# Altium schematic sheet Display.SchDoc
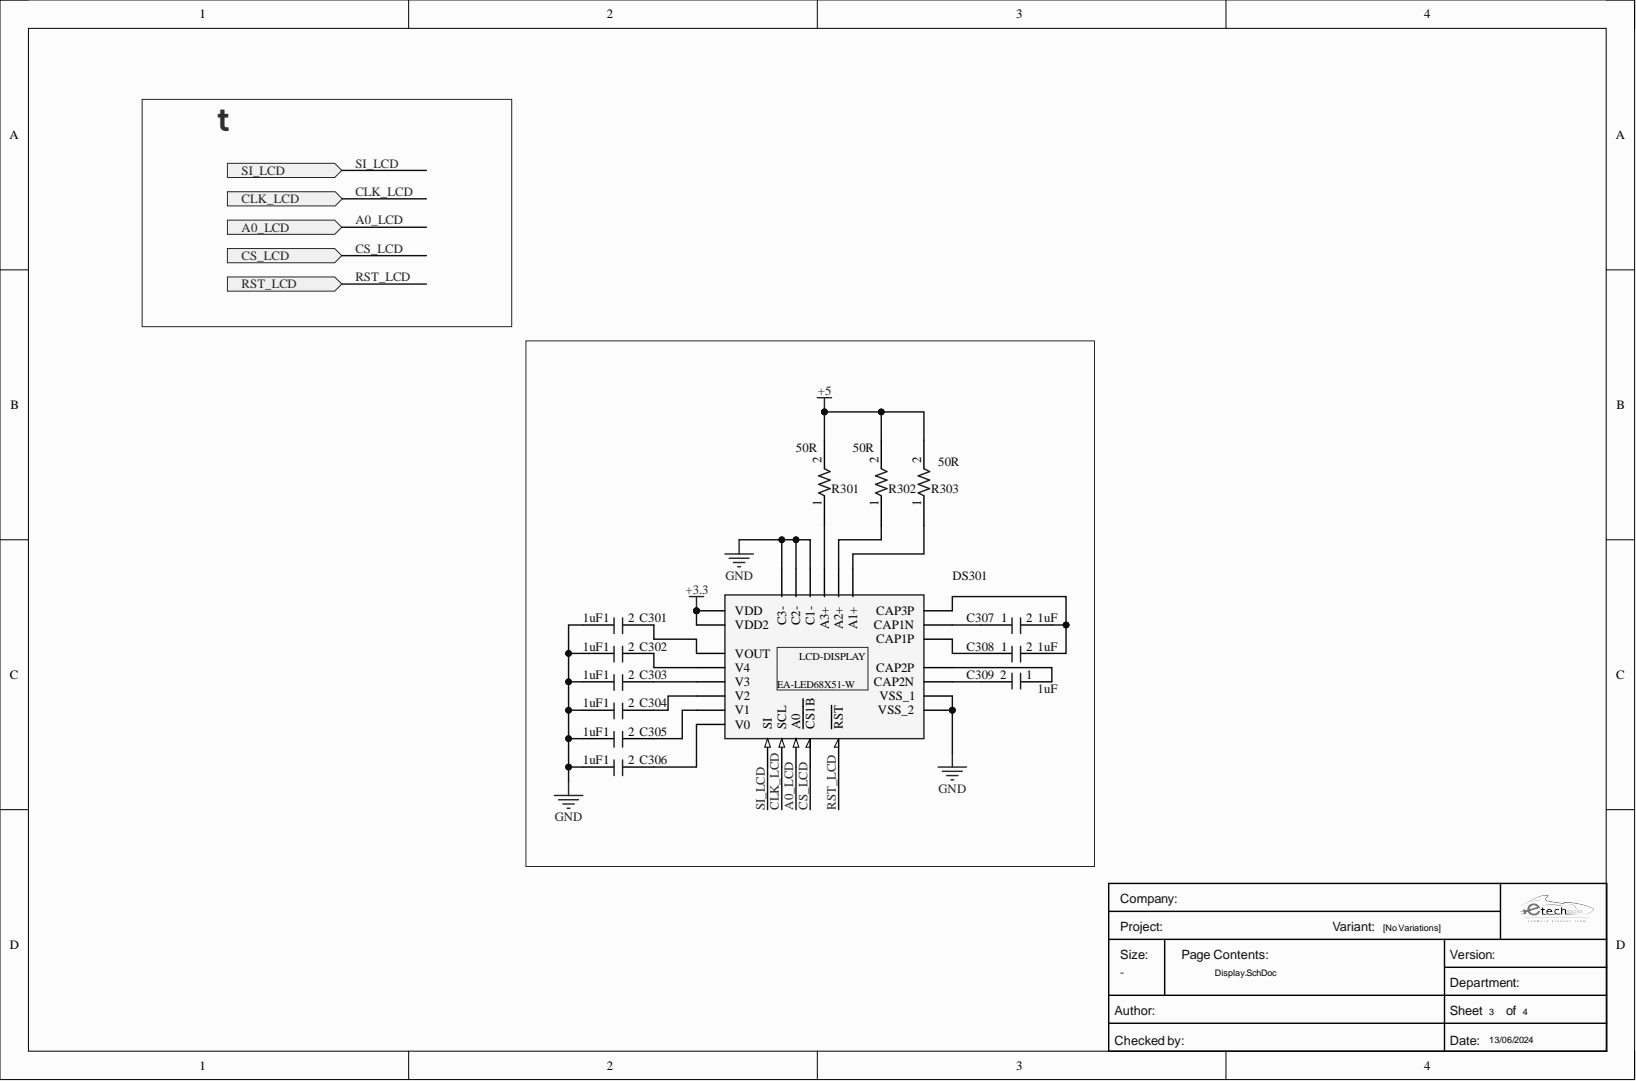
[diagram p0: Display.SchDoc]

## Parts
C301  Capacitance: 1uF  [C0805FNPC-R]  (hidden comment: 885012207103)
C302  Capacitance: 1uF  [C0805FNPC-R]  (hidden comment: 885012207103)
C303  Capacitance: 1uF  [C0805FNPC-R]  (hidden comment: 885012207103)
C304  Capacitance: 1uF  [C0805FNPC-R]  (hidden comment: 885012207103)
C305  Capacitance: 1uF  [C0805FNPC-R]  (hidden comment: 885012207103)
C306  Capacitance: 1uF  [C0805FNPC-R]  (hidden comment: 885012207103)
C307  Capacitance: 1uF  [C0805FNPC-R]  (hidden comment: 885012207103)
C308  Capacitance: 1uF  [C0805FNPC-R]  (hidden comment: 885012207103)
C309  Capacitance: 1uF  [C0805FNPC-R]  (hidden comment: 885012207103)
DS301  EA_DOGL128W-6  [EA_DOGL128W-6]  (value not drawn)
R301  value 50R  [R0805FTK]  (hidden comment: CRCW080550R0FKTA)
R302  value 50R  [R0805FTK]  (hidden comment: CRCW080550R0FKTA)
R303  value 50R  [R0805FTK]  (hidden comment: CRCW080550R0FKTA)

## Ports
A0_LCD, CLK_LCD, CS_LCD, RST_LCD, SI_LCD

## Nets
+3.3: DS301.34(VDD2) DS301.35(VDD)
+5: R301.2 R302.2 R303.2
GND: C301.1 C302.1 C303.1 C304.1 C305.1 C306.1 DS301.18(C1-) DS301.19(C2-) DS301.20(C3-) DS301.26(VSS_1) DS301.33(VSS_2)
A0_LCD: DS301.38(A0) ; port A0_LCD
CLK_LCD: DS301.37(SCL) ; port CLK_LCD
CS_LCD: DS301.40(CS1B) ; port CS_LCD
RST_LCD: DS301.39(RST) ; port RST_LCD
SI_LCD: DS301.36(SI) ; port SI_LCD
NetC301_2: C301.2 DS301.32(VOUT)
NetC302_2: C302.2 DS301.25(V4)
NetC303_2: C303.2 DS301.24(V3)
NetC304_2: C304.2 DS301.23(V2)
NetC305_2: C305.2 DS301.22(V1)
NetC306_2: C306.2 DS301.21(V0)
NetC307_1: C307.1 DS301.30(CAP1N)
NetC307_2: C307.2 C308.2 DS301.31(CAP3P)
NetC308_1: C308.1 DS301.29(CAP1P)
NetC309_1: C309.1 DS301.28(CAP2P)
NetC309_2: C309.2 DS301.27(CAP2N)
NetDS301_1: DS301.1(A1+) R303.1
NetDS301_2: DS301.2(A2+) R302.1
NetDS301_3: DS301.3(A3+) R301.1

## Library data of the parts
C301-C309 = C1u-50V-0805FNPC-X7R: Multilayer Ceramic Capacitor, Wcap-Csgp Series, 1 F, 10%, X7R, 50 V, 0805 [2012 Metric] Rohs Compliant: Yes; Manufacturer 1: Wurth Electronics; .Creator: GP; Voltage Rating: 50V; Case Code (Imperial): 0805; Case Code (Metric): 2012; Case/Package: 0805; Category: Ceramic Capacitors; Component Kind: Standard; ComponentLink1Description: http://www.farnell.com/datasheets/1958501.pdf?src-supplier=Farnell; ComponentLink1URL: http://www.farnell.com/datasheets/1958501.pdf?src-supplier=Farnell; ComponentLink2Description: http://www.farnell.com/datasheets/1958501.pdf?src-supplier=Element14; ComponentLink2URL: http://www.farnell.com/datasheets/1958501.pdf?src-supplier=Element14; ComponentLink3Description: https://katalog.we-online.de/pbs/datasheet/885012107003.pdf?src-supplier=Digi-Key; ComponentLink3URL: https://katalog.we-online.de/pbs/datasheet/885012107003.pdf?src-supplier=Digi-Key; ComponentLink4Description: http://www.farnell.com/datasheets/1958501.pdf?src-supplier=Newark; ComponentLink4URL: http://www.farnell.com/datasheets/1958501.pdf?src-supplier=Newark; Dielectric: X7R; Length: 0.079inch; Library Name: Passives.SchLib; Manufacturer Part Number 1: 885012207103; Max Operating Temperature: 85°C; Min Operating Temperature: -55°C; Mount: Surface Mount; Packaging: Cut Tape; Pricing 1: 50=0,27200, 250=0,25300, 500=0,22800, 1250=0,20100, 2500=0,17100 (GBP); REACH SVHC: Unknown; RoHS: Yes; RoHS Compliant: Yes; Stock 1: 5100; Supplier 1: RSComponents; Supplier Part Number 1: 1813523; Thickness: 0.053inch; Tolerance: 10%; Voltage Rating (DC): 50V; Width: 0.049inch
DS301 = EA_DOGL128W-6: LCD Graphic Display Modules & Accessories FSTN (+) Transflectv White Background; Manufacturer: Electronic Assembly; Manufacturer Part Number: EADOGL128W-6; Manufacturer_Name: Electronic Assembly GmbH; Manufacturer_Part_Number: EA DOGL128W-6; .Creator: GP; Interface: SPI; Nominal Supply Current: 270uA; Resolution (height): 64pixel; Resolution (width): 128pixel; Viewing Area (height): 35mm; Viewing Area (width): 64mm; Background Color: White; Category: Display; Component Kind: Standard; ComponentLink1Description: Datasheet; ComponentLink1URL: http://www.lcd-module.com/eng/pdf/grafik/dogl128-6e.pdf; ComponentLink2Description: Where buy it; ComponentLink3Description: https://datasheet.ciiva.com/14100/dogl128-6-eng-tds-14100350.pdf?src-supplier=Distrelec; ComponentLink3URL: https://datasheet.ciiva.com/14100/dogl128-6-eng-tds-14100350.pdf?src-supplier=Distrelec; Height: 2.8mm; Illumination Color: White; Library Name: Integrated circuits.SchLib; Max Operating Temperature: 70°C; Min Operating Temperature: -20°C; Package Quantity: 16; RoHS: No; Supplier 1: Digi-Key; Supplier Part Number 1: 1481-1058-ND; Width: 67.8mm
R301-R303 = R50-0805FTK: Res Thick Film 0805 50 Ohm 1% 0.125W(1/8W) ±100ppm/C Pad SMD Automotive T/R; Manufacturer: Vishay Dale; Power Rating: 125mW; Temperature Coefficient: 100ppm/°C; Voltage Rating: 150V; Case Code (Imperial): 0805; Case Code (Metric): 2012; Case/Package: 0805; Category: Chip SMD Resistors; Component Kind: Standard; ComponentLink1Description: https://datasheet.ciiva.com/48525/dcrcw-48525895.pdf?src-supplier=Avnet; ComponentLink1URL: https://datasheet.ciiva.com/48525/dcrcw-48525895.pdf?src-supplier=Avnet; Contact Plating: Tin,Lead; Height: 0.45mm; Lead Free: Contains Lead; Length: 2mm; Library Name: Passives.SchLib; Manufacturer Part Number: CRCW080550R0FKTA; Max Operating Temperature: 155°C; Min Operating Temperature: -55°C; Package Quantity: 5000; Packaging: Tape and Reel; Pricing 1: 1=0,25, 10=0,204, 50=0,204, 100=0,08, 1000=0,033, 10000=0,026 (USD); RoHS: No; RoHS Compliant: No; Series: CRCW; Stock 1: 38245; Supplier 1: Mouser; Supplier Part Number 1: 71-CRCW0805-50; Tolerance: 1%; Weight: 0.000194oz; Width: 1.25mm
